# Altium schematic sheet 1_flatsat_interfaces.SchDoc(1_flatsat_interfaces)
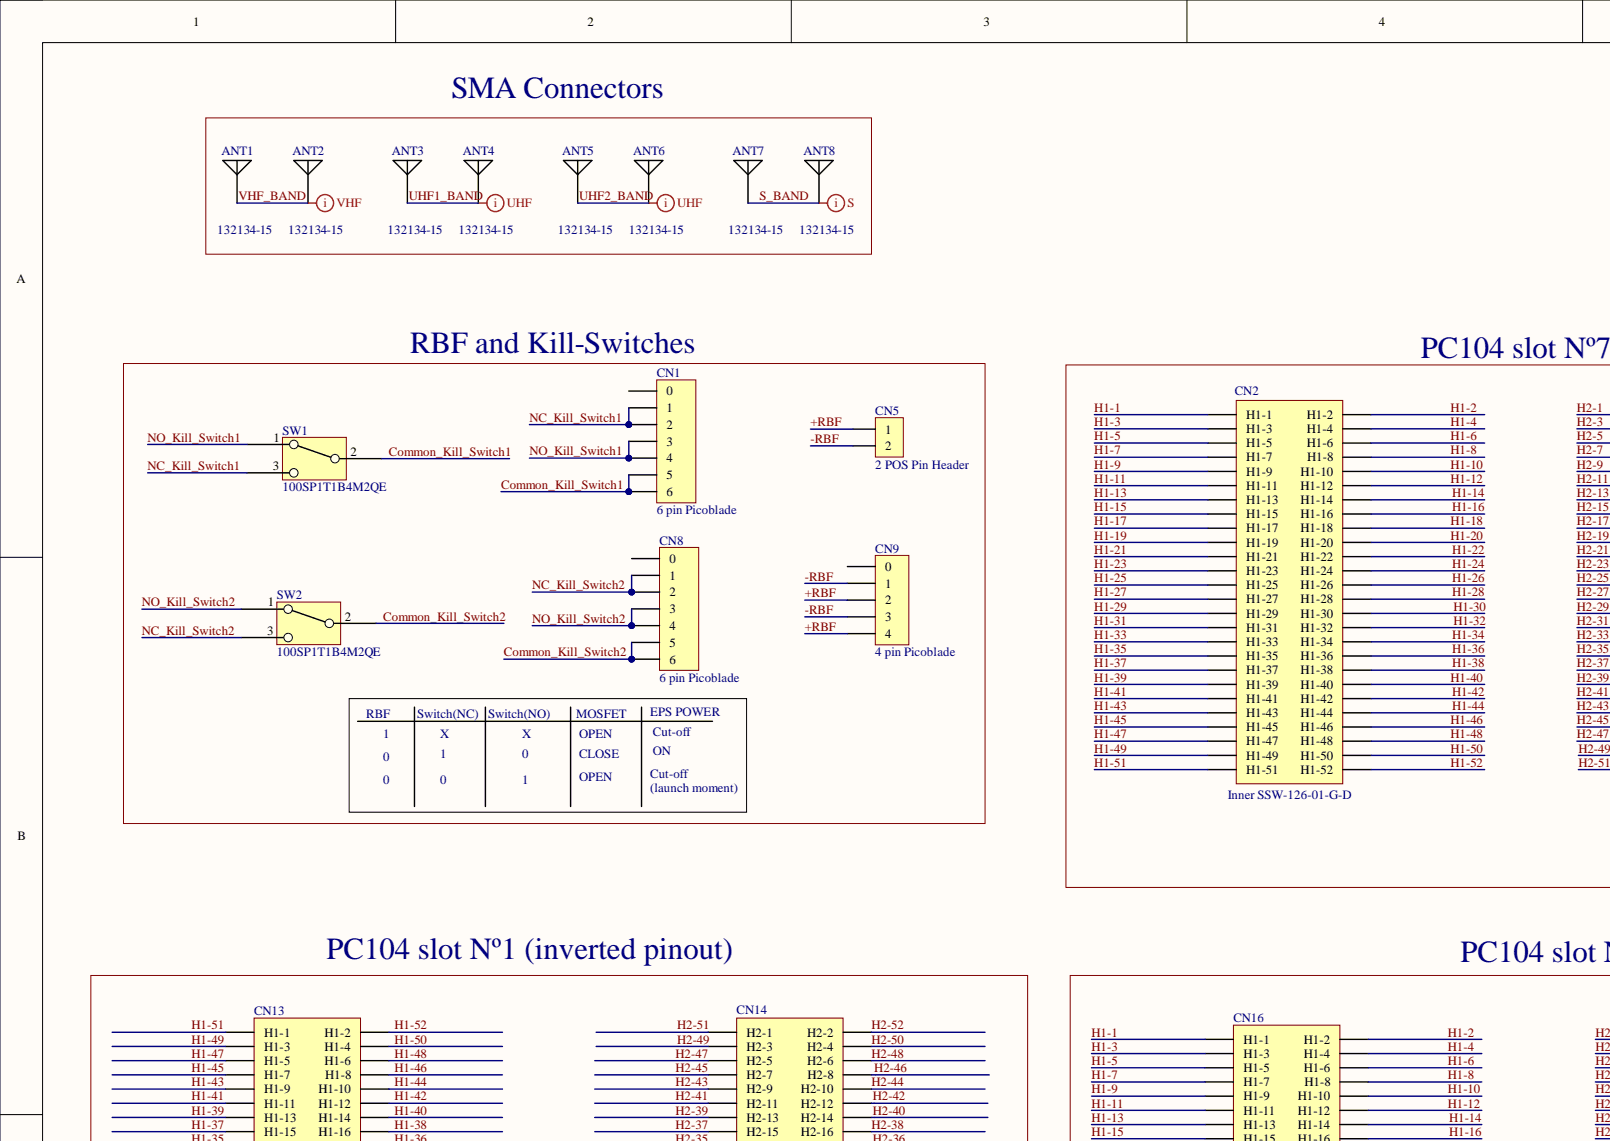
[diagram p0: 1_flatsat_interfaces.SchDoc, top left]
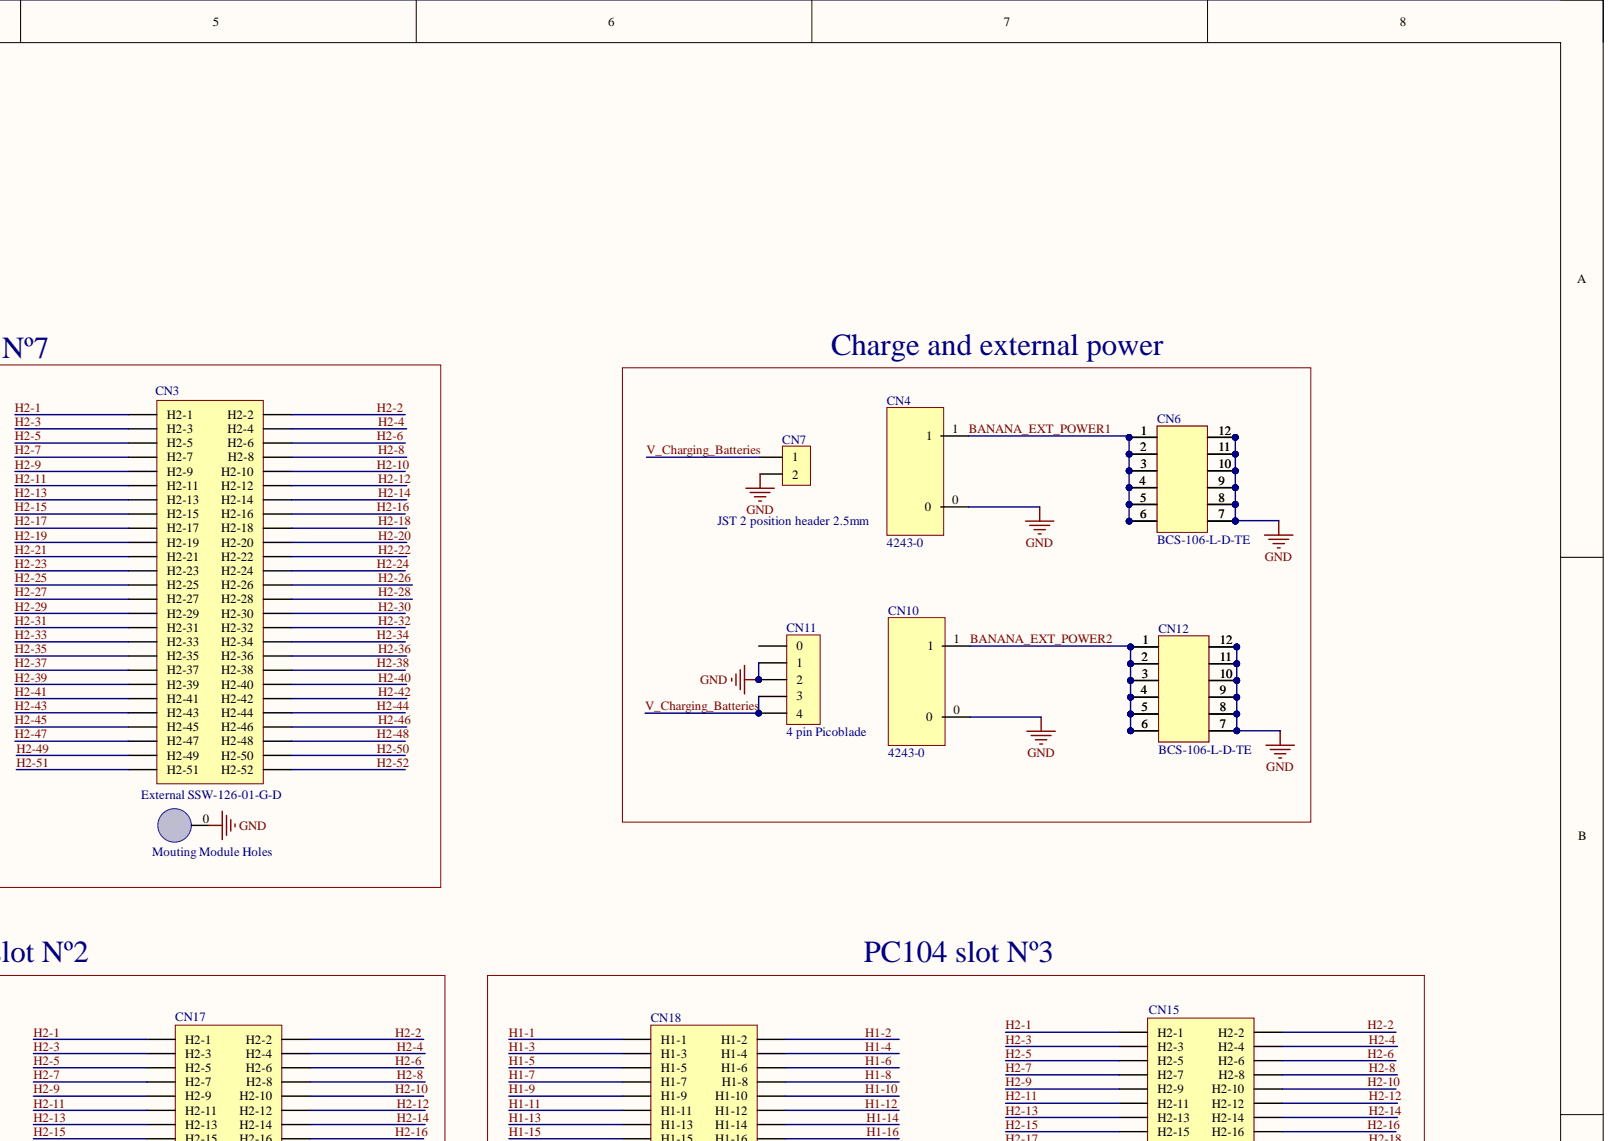
[diagram p1: 1_flatsat_interfaces.SchDoc, top right]
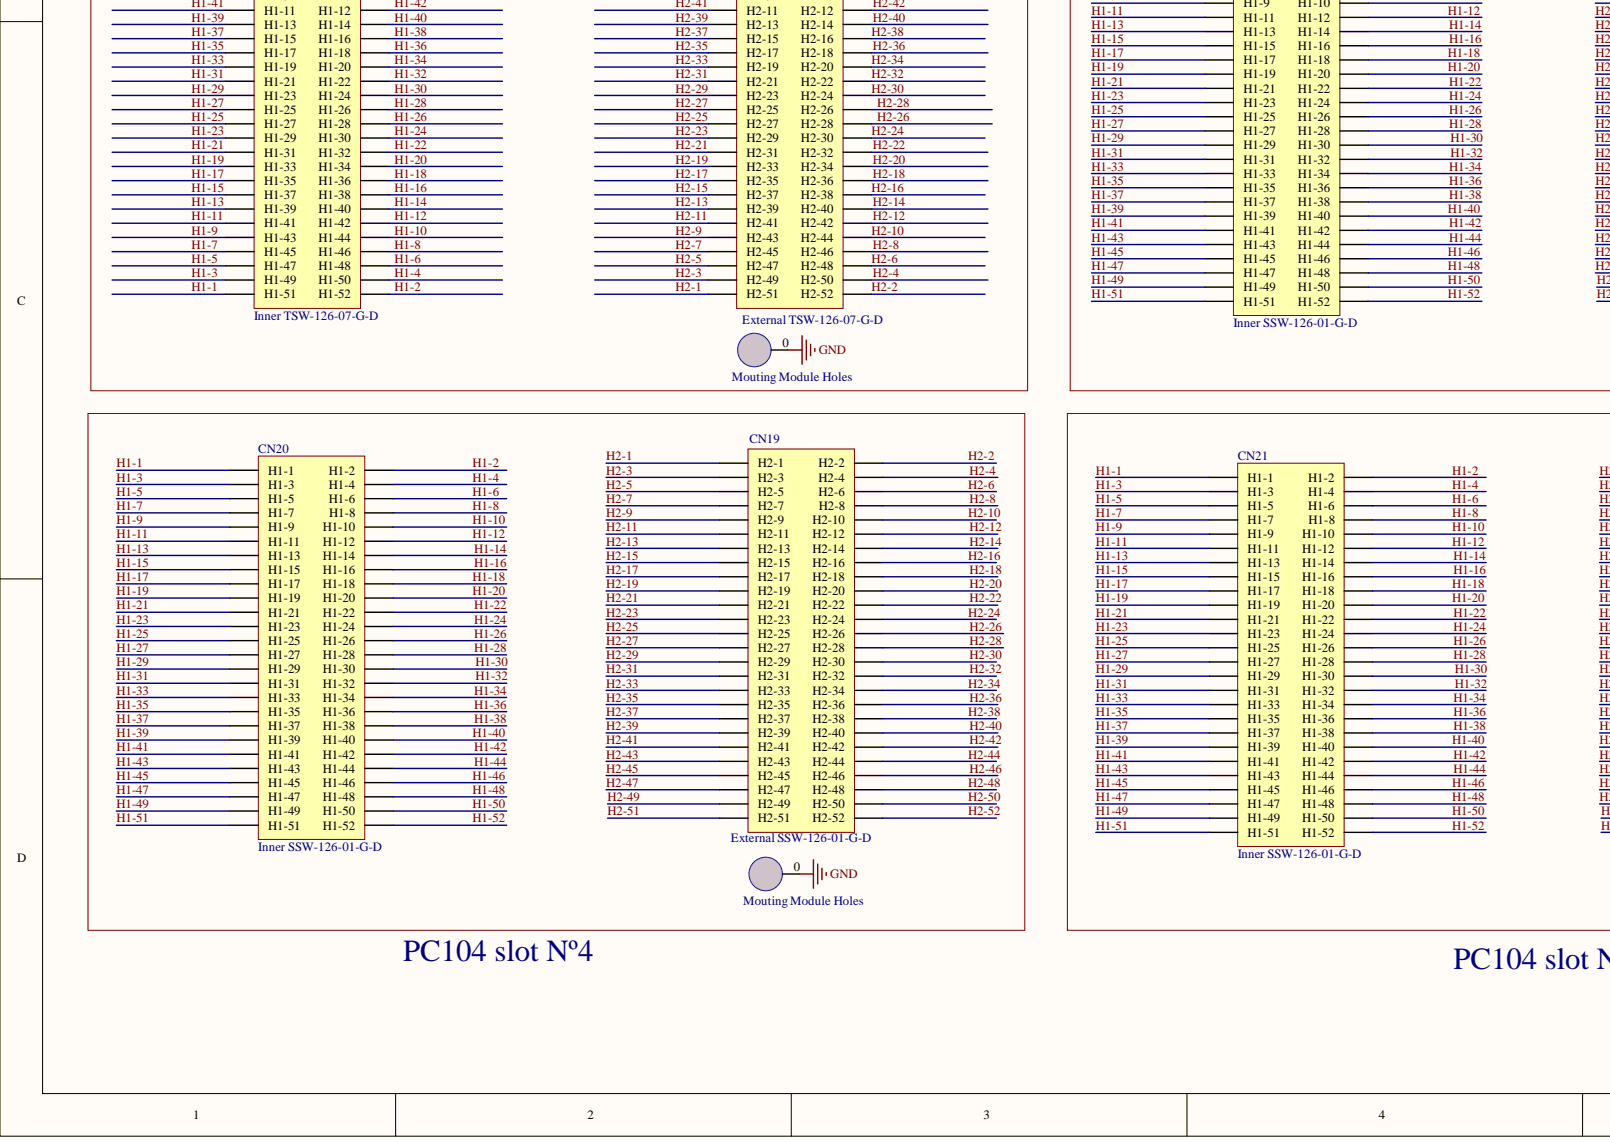
[diagram p2: 1_flatsat_interfaces.SchDoc, bottom left]
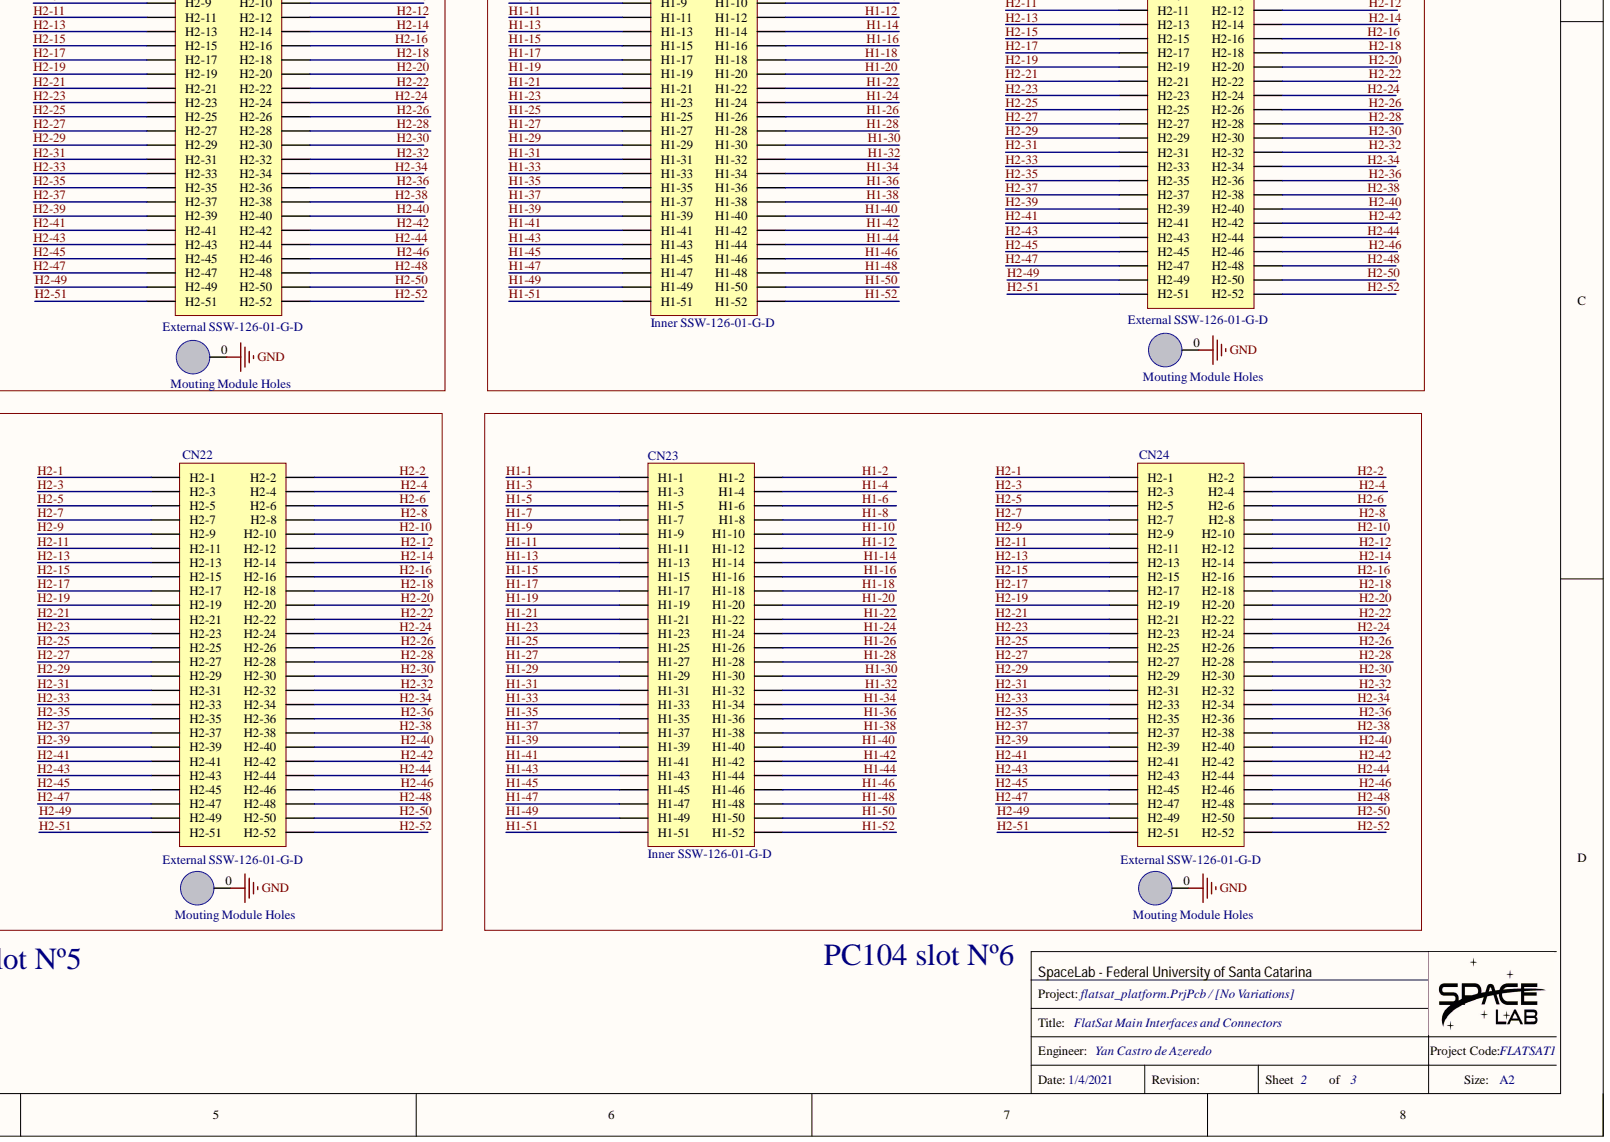
[diagram p3: 1_flatsat_interfaces.SchDoc, bottom right]

## Parts
ANT1  132134-15  [132134-15]
ANT2  132134-15  [132134-15]
ANT3  132134-15  [132134-15]
ANT4  132134-15  [132134-15]
ANT5  132134-15  [132134-15]
ANT6  132134-15  [132134-15]
ANT7  132134-15  [132134-15]
ANT8  132134-15  [132134-15]
CN1  6 pin Picoblade  [PICO BLADE 0533980671]
CN2  Inner SSW-126-01-G-D  Manufacturer Part Number: SSW-126-01-G-D  [Inner_SSW-126-01-G-D_testboard]
CN3  External SSW-126-01-G-D  Manufacturer Part Number: SSW-126-01-G-D  [external_SSW-126-01-G-D _testboard]
CN4  4243-0  [4243-0]
CN5  2 POS Pin Header  [CONN HEADER VERT 2POS 2.54mm]
CN6  BCS-106-L-D-TE  [BCS-106-L-D-TE]
CN7  JST 2 position header 2.5mm  Manufacturer: JST  [B2B-XH-A-M(LF)(SN)]
CN8  6 pin Picoblade  [PICO BLADE 0533980671]
CN9  4 pin Picoblade  [PICO BLADE 0533980471]
CN10  4243-0  [4243-0]
CN11  4 pin Picoblade  [PICO BLADE 0533980471]
CN12  BCS-106-L-D-TE  [BCS-106-L-D-TE]
CN13  Inner TSW-126-07-G-D  Manufacturer Part Number: TSW-126-07-G-D  [Inner_TSW-126-07-G-D]
CN14  External TSW-126-07-G-D  Manufacturer Part Number: TSW-126-07-G-D  [external_TSW-126-07-G-D]
CN15  External SSW-126-01-G-D  Manufacturer Part Number: SSW-126-01-G-D  [external_SSW-126-01-G-D]
CN16  Inner SSW-126-01-G-D  Manufacturer Part Number: SSW-126-01-G-D  [Inner_SSW-126-01-G-D]
CN17  External SSW-126-01-G-D  Manufacturer Part Number: SSW-126-01-G-D  [external_SSW-126-01-G-D]
CN18  Inner SSW-126-01-G-D  Manufacturer Part Number: SSW-126-01-G-D  [Inner_SSW-126-01-G-D]
CN19  External SSW-126-01-G-D  Manufacturer Part Number: SSW-126-01-G-D  [external_SSW-126-01-G-D]
CN20  Inner SSW-126-01-G-D  Manufacturer Part Number: SSW-126-01-G-D  [Inner_SSW-126-01-G-D]
CN21  Inner SSW-126-01-G-D  Manufacturer Part Number: SSW-126-01-G-D  [Inner_SSW-126-01-G-D]
CN22  External SSW-126-01-G-D  Manufacturer Part Number: SSW-126-01-G-D  [external_SSW-126-01-G-D]
CN23  Inner SSW-126-01-G-D  Manufacturer Part Number: SSW-126-01-G-D  [Inner_SSW-126-01-G-D]
CN24  External SSW-126-01-G-D  Manufacturer Part Number: SSW-126-01-G-D  [external_SSW-126-01-G-D]
SW1  100SP1T1B4M2QE  [Toggle Switch SPDT Through Hole]
SW2  100SP1T1B4M2QE  [Toggle Switch SPDT Through Hole]

## Nets
GND: CN3.0 CN4.0 CN6.7 CN6.8 CN6.9 CN6.10 CN6.11 CN6.12 CN7.2 CN10.0 CN11.1 CN11.2 CN12.7 CN12.8 CN12.9 CN12.10 CN12.11 CN12.12 CN14.0 CN15.0 CN17.0 CN19.0 CN22.0 CN24.0
+RBF: CN5.1 CN9.2 CN9.4
-RBF: CN5.2 CN9.1 CN9.3
BANANA_EXT_POWER1: CN4.1 CN6.1 CN6.2 CN6.3 CN6.4 CN6.5 CN6.6
BANANA_EXT_POWER2: CN10.1 CN12.1 CN12.2 CN12.3 CN12.4 CN12.5 CN12.6
Common_Kill_Switch1: CN1.5 CN1.6 SW1.2
Common_Kill_Switch2: CN8.5 CN8.6 SW2.2
H1-1: CN2.H1-1 CN13.H1-51 CN16.H1-1 CN18.H1-1 CN20.H1-1 CN21.H1-1 CN23.H1-1
H1-2: CN2.H1-2 CN13.H1-52 CN16.H1-2 CN18.H1-2 CN20.H1-2 CN21.H1-2 CN23.H1-2
H1-3: CN2.H1-3 CN13.H1-49 CN16.H1-3 CN18.H1-3 CN20.H1-3 CN21.H1-3 CN23.H1-3
H1-4: CN2.H1-4 CN13.H1-50 CN16.H1-4 CN18.H1-4 CN20.H1-4 CN21.H1-4 CN23.H1-4
H1-5: CN2.H1-5 CN13.H1-47 CN16.H1-5 CN18.H1-5 CN20.H1-5 CN21.H1-5 CN23.H1-5
H1-6: CN2.H1-6 CN13.H1-48 CN16.H1-6 CN18.H1-6 CN20.H1-6 CN21.H1-6 CN23.H1-6
H1-7: CN2.H1-7 CN13.H1-45 CN16.H1-7 CN18.H1-7 CN20.H1-7 CN21.H1-7 CN23.H1-7
H1-8: CN2.H1-8 CN13.H1-46 CN16.H1-8 CN18.H1-8 CN20.H1-8 CN21.H1-8 CN23.H1-8
H1-9: CN2.H1-9 CN13.H1-43 CN16.H1-9 CN18.H1-9 CN20.H1-9 CN21.H1-9 CN23.H1-9
H1-10: CN2.H1-10 CN13.H1-44 CN16.H1-10 CN18.H1-10 CN20.H1-10 CN21.H1-10 CN23.H1-10
H1-11: CN2.H1-11 CN13.H1-41 CN16.H1-11 CN18.H1-11 CN20.H1-11 CN21.H1-11 CN23.H1-11
H1-12: CN2.H1-12 CN13.H1-42 CN16.H1-12 CN18.H1-12 CN20.H1-12 CN21.H1-12 CN23.H1-12
H1-13: CN2.H1-13 CN13.H1-39 CN16.H1-13 CN18.H1-13 CN20.H1-13 CN21.H1-13 CN23.H1-13
H1-14: CN2.H1-14 CN13.H1-40 CN16.H1-14 CN18.H1-14 CN20.H1-14 CN21.H1-14 CN23.H1-14
H1-15: CN2.H1-15 CN13.H1-37 CN16.H1-15 CN18.H1-15 CN20.H1-15 CN21.H1-15 CN23.H1-15
H1-16: CN2.H1-16 CN13.H1-38 CN16.H1-16 CN18.H1-16 CN20.H1-16 CN21.H1-16 CN23.H1-16
H1-17: CN2.H1-17 CN13.H1-35 CN16.H1-17 CN18.H1-17 CN20.H1-17 CN21.H1-17 CN23.H1-17
H1-18: CN2.H1-18 CN13.H1-36 CN16.H1-18 CN18.H1-18 CN20.H1-18 CN21.H1-18 CN23.H1-18
H1-19: CN2.H1-19 CN13.H1-33 CN16.H1-19 CN18.H1-19 CN20.H1-19 CN21.H1-19 CN23.H1-19
H1-20: CN2.H1-20 CN13.H1-34 CN16.H1-20 CN18.H1-20 CN20.H1-20 CN21.H1-20 CN23.H1-20
H1-21: CN2.H1-21 CN13.H1-31 CN16.H1-21 CN18.H1-21 CN20.H1-21 CN21.H1-21 CN23.H1-21
H1-22: CN2.H1-22 CN13.H1-32 CN16.H1-22 CN18.H1-22 CN20.H1-22 CN21.H1-22 CN23.H1-22
H1-23: CN2.H1-23 CN13.H1-29 CN16.H1-23 CN18.H1-23 CN20.H1-23 CN21.H1-23 CN23.H1-23
H1-24: CN2.H1-24 CN13.H1-30 CN16.H1-24 CN18.H1-24 CN20.H1-24 CN21.H1-24 CN23.H1-24
H1-25: CN2.H1-25 CN13.H1-27 CN16.H1-25 CN18.H1-25 CN20.H1-25 CN21.H1-25 CN23.H1-25
H1-26: CN2.H1-26 CN13.H1-28 CN16.H1-26 CN18.H1-26 CN20.H1-26 CN21.H1-26 CN23.H1-26
H1-27: CN2.H1-27 CN13.H1-25 CN16.H1-27 CN18.H1-27 CN20.H1-27 CN21.H1-27 CN23.H1-27
H1-28: CN2.H1-28 CN13.H1-26 CN16.H1-28 CN18.H1-28 CN20.H1-28 CN21.H1-28 CN23.H1-28
H1-29: CN2.H1-29 CN13.H1-23 CN16.H1-29 CN18.H1-29 CN20.H1-29 CN21.H1-29 CN23.H1-29
H1-30: CN2.H1-30 CN13.H1-24 CN16.H1-30 CN18.H1-30 CN20.H1-30 CN21.H1-30 CN23.H1-30
H1-31: CN2.H1-31 CN13.H1-21 CN16.H1-31 CN18.H1-31 CN20.H1-31 CN21.H1-31 CN23.H1-31
H1-32: CN2.H1-32 CN13.H1-22 CN16.H1-32 CN18.H1-32 CN20.H1-32 CN21.H1-32 CN23.H1-32
H1-33: CN2.H1-33 CN13.H1-19 CN16.H1-33 CN18.H1-33 CN20.H1-33 CN21.H1-33 CN23.H1-33
H1-34: CN2.H1-34 CN13.H1-20 CN16.H1-34 CN18.H1-34 CN20.H1-34 CN21.H1-34 CN23.H1-34
H1-35: CN2.H1-35 CN13.H1-17 CN16.H1-35 CN18.H1-35 CN20.H1-35 CN21.H1-35 CN23.H1-35
H1-36: CN2.H1-36 CN13.H1-18 CN16.H1-36 CN18.H1-36 CN20.H1-36 CN21.H1-36 CN23.H1-36
H1-37: CN2.H1-37 CN13.H1-15 CN16.H1-37 CN18.H1-37 CN20.H1-37 CN21.H1-37 CN23.H1-37
H1-38: CN2.H1-38 CN13.H1-16 CN16.H1-38 CN18.H1-38 CN20.H1-38 CN21.H1-38 CN23.H1-38
H1-39: CN2.H1-39 CN13.H1-13 CN16.H1-39 CN18.H1-39 CN20.H1-39 CN21.H1-39 CN23.H1-39
H1-40: CN2.H1-40 CN13.H1-14 CN16.H1-40 CN18.H1-40 CN20.H1-40 CN21.H1-40 CN23.H1-40
H1-41: CN2.H1-41 CN13.H1-11 CN16.H1-41 CN18.H1-41 CN20.H1-41 CN21.H1-41 CN23.H1-41
H1-42: CN2.H1-42 CN13.H1-12 CN16.H1-42 CN18.H1-42 CN20.H1-42 CN21.H1-42 CN23.H1-42
H1-43: CN2.H1-43 CN13.H1-9 CN16.H1-43 CN18.H1-43 CN20.H1-43 CN21.H1-43 CN23.H1-43
H1-44: CN2.H1-44 CN13.H1-10 CN16.H1-44 CN18.H1-44 CN20.H1-44 CN21.H1-44 CN23.H1-44
H1-45: CN2.H1-45 CN13.H1-7 CN16.H1-45 CN18.H1-45 CN20.H1-45 CN21.H1-45 CN23.H1-45
H1-46: CN2.H1-46 CN13.H1-8 CN16.H1-46 CN18.H1-46 CN20.H1-46 CN21.H1-46 CN23.H1-46
H1-47: CN2.H1-47 CN13.H1-5 CN16.H1-47 CN18.H1-47 CN20.H1-47 CN21.H1-47 CN23.H1-47
H1-48: CN2.H1-48 CN13.H1-6 CN16.H1-48 CN18.H1-48 CN20.H1-48 CN21.H1-48 CN23.H1-48
H1-49: CN2.H1-49 CN13.H1-3 CN16.H1-49 CN18.H1-49 CN20.H1-49 CN21.H1-49 CN23.H1-49
H1-50: CN2.H1-50 CN13.H1-4 CN16.H1-50 CN18.H1-50 CN20.H1-50 CN21.H1-50 CN23.H1-50
H1-51: CN2.H1-51 CN13.H1-1 CN16.H1-51 CN18.H1-51 CN20.H1-51 CN21.H1-51 CN23.H1-51
H1-52: CN2.H1-52 CN13.H1-2 CN16.H1-52 CN18.H1-52 CN20.H1-52 CN21.H1-52 CN23.H1-52
H2-1: CN3.H2-1 CN14.H2-51 CN15.H2-1 CN17.H2-1 CN19.H2-1 CN22.H2-1 CN24.H2-1
H2-2: CN3.H2-2 CN14.H2-52 CN15.H2-2 CN17.H2-2 CN19.H2-2 CN22.H2-2 CN24.H2-2
H2-3: CN3.H2-3 CN14.H2-49 CN15.H2-3 CN17.H2-3 CN19.H2-3 CN22.H2-3 CN24.H2-3
H2-4: CN3.H2-4 CN14.H2-50 CN15.H2-4 CN17.H2-4 CN19.H2-4 CN22.H2-4 CN24.H2-4
H2-5: CN3.H2-5 CN14.H2-47 CN15.H2-5 CN17.H2-5 CN19.H2-5 CN22.H2-5 CN24.H2-5
H2-6: CN3.H2-6 CN14.H2-48 CN15.H2-6 CN17.H2-6 CN19.H2-6 CN22.H2-6 CN24.H2-6
H2-7: CN3.H2-7 CN14.H2-45 CN15.H2-7 CN17.H2-7 CN19.H2-7 CN22.H2-7 CN24.H2-7
H2-8: CN3.H2-8 CN14.H2-46 CN15.H2-8 CN17.H2-8 CN19.H2-8 CN22.H2-8 CN24.H2-8
H2-9: CN3.H2-9 CN14.H2-43 CN15.H2-9 CN17.H2-9 CN19.H2-9 CN22.H2-9 CN24.H2-9
H2-10: CN3.H2-10 CN14.H2-44 CN15.H2-10 CN17.H2-10 CN19.H2-10 CN22.H2-10 CN24.H2-10
H2-11: CN3.H2-11 CN14.H2-41 CN15.H2-11 CN17.H2-11 CN19.H2-11 CN22.H2-11 CN24.H2-11
H2-12: CN3.H2-12 CN14.H2-42 CN15.H2-12 CN17.H2-12 CN19.H2-12 CN22.H2-12 CN24.H2-12
H2-13: CN3.H2-13 CN14.H2-39 CN15.H2-13 CN17.H2-13 CN19.H2-13 CN22.H2-13 CN24.H2-13
H2-14: CN3.H2-14 CN14.H2-40 CN15.H2-14 CN17.H2-14 CN19.H2-14 CN22.H2-14 CN24.H2-14
H2-15: CN3.H2-15 CN14.H2-37 CN15.H2-15 CN17.H2-15 CN19.H2-15 CN22.H2-15 CN24.H2-15
H2-16: CN3.H2-16 CN14.H2-38 CN15.H2-16 CN17.H2-16 CN19.H2-16 CN22.H2-16 CN24.H2-16
H2-17: CN3.H2-17 CN14.H2-35 CN15.H2-17 CN17.H2-17 CN19.H2-17 CN22.H2-17 CN24.H2-17
H2-18: CN3.H2-18 CN14.H2-36 CN15.H2-18 CN17.H2-18 CN19.H2-18 CN22.H2-18 CN24.H2-18
H2-19: CN3.H2-19 CN14.H2-33 CN15.H2-19 CN17.H2-19 CN19.H2-19 CN22.H2-19 CN24.H2-19
H2-20: CN3.H2-20 CN14.H2-34 CN15.H2-20 CN17.H2-20 CN19.H2-20 CN22.H2-20 CN24.H2-20
H2-21: CN3.H2-21 CN14.H2-31 CN15.H2-21 CN17.H2-21 CN19.H2-21 CN22.H2-21 CN24.H2-21
H2-22: CN3.H2-22 CN14.H2-32 CN15.H2-22 CN17.H2-22 CN19.H2-22 CN22.H2-22 CN24.H2-22
H2-23: CN3.H2-23 CN14.H2-29 CN15.H2-23 CN17.H2-23 CN19.H2-23 CN22.H2-23 CN24.H2-23
H2-24: CN3.H2-24 CN14.H2-30 CN15.H2-24 CN17.H2-24 CN19.H2-24 CN22.H2-24 CN24.H2-24
H2-25: CN3.H2-25 CN14.H2-27 CN15.H2-25 CN17.H2-25 CN19.H2-25 CN22.H2-25 CN24.H2-25
H2-26: CN3.H2-26 CN14.H2-28 CN15.H2-26 CN17.H2-26 CN19.H2-26 CN22.H2-26 CN24.H2-26
H2-27: CN3.H2-27 CN14.H2-25 CN15.H2-27 CN17.H2-27 CN19.H2-27 CN22.H2-27 CN24.H2-27
H2-28: CN3.H2-28 CN14.H2-26 CN15.H2-28 CN17.H2-28 CN19.H2-28 CN22.H2-28 CN24.H2-28
H2-29: CN3.H2-29 CN14.H2-23 CN15.H2-29 CN17.H2-29 CN19.H2-29 CN22.H2-29 CN24.H2-29
H2-30: CN3.H2-30 CN14.H2-24 CN15.H2-30 CN17.H2-30 CN19.H2-30 CN22.H2-30 CN24.H2-30
H2-31: CN3.H2-31 CN14.H2-21 CN15.H2-31 CN17.H2-31 CN19.H2-31 CN22.H2-31 CN24.H2-31
H2-32: CN3.H2-32 CN14.H2-22 CN15.H2-32 CN17.H2-32 CN19.H2-32 CN22.H2-32 CN24.H2-32
H2-33: CN3.H2-33 CN14.H2-19 CN15.H2-33 CN17.H2-33 CN19.H2-33 CN22.H2-33 CN24.H2-33
H2-34: CN3.H2-34 CN14.H2-20 CN15.H2-34 CN17.H2-34 CN19.H2-34 CN22.H2-34 CN24.H2-34
H2-35: CN3.H2-35 CN14.H2-17 CN15.H2-35 CN17.H2-35 CN19.H2-35 CN22.H2-35 CN24.H2-35
H2-36: CN3.H2-36 CN14.H2-18 CN15.H2-36 CN17.H2-36 CN19.H2-36 CN22.H2-36 CN24.H2-36
H2-37: CN3.H2-37 CN14.H2-15 CN15.H2-37 CN17.H2-37 CN19.H2-37 CN22.H2-37 CN24.H2-37
H2-38: CN3.H2-38 CN14.H2-16 CN15.H2-38 CN17.H2-38 CN19.H2-38 CN22.H2-38 CN24.H2-38
H2-39: CN3.H2-39 CN14.H2-13 CN15.H2-39 CN17.H2-39 CN19.H2-39 CN22.H2-39 CN24.H2-39
H2-40: CN3.H2-40 CN14.H2-14 CN15.H2-40 CN17.H2-40 CN19.H2-40 CN22.H2-40 CN24.H2-40
H2-41: CN3.H2-41 CN14.H2-11 CN15.H2-41 CN17.H2-41 CN19.H2-41 CN22.H2-41 CN24.H2-41
H2-42: CN3.H2-42 CN14.H2-12 CN15.H2-42 CN17.H2-42 CN19.H2-42 CN22.H2-42 CN24.H2-42
H2-43: CN3.H2-43 CN14.H2-9 CN15.H2-43 CN17.H2-43 CN19.H2-43 CN22.H2-43 CN24.H2-43
H2-44: CN3.H2-44 CN14.H2-10 CN15.H2-44 CN17.H2-44 CN19.H2-44 CN22.H2-44 CN24.H2-44
H2-45: CN3.H2-45 CN14.H2-7 CN15.H2-45 CN17.H2-45 CN19.H2-45 CN22.H2-45 CN24.H2-45
H2-46: CN3.H2-46 CN14.H2-8 CN15.H2-46 CN17.H2-46 CN19.H2-46 CN22.H2-46 CN24.H2-46
H2-47: CN3.H2-47 CN14.H2-5 CN15.H2-47 CN17.H2-47 CN19.H2-47 CN22.H2-47 CN24.H2-47
H2-48: CN3.H2-48 CN14.H2-6 CN15.H2-48 CN17.H2-48 CN19.H2-48 CN22.H2-48 CN24.H2-48
H2-49: CN3.H2-49 CN14.H2-3 CN15.H2-49 CN17.H2-49 CN19.H2-49 CN22.H2-49 CN24.H2-49
H2-50: CN3.H2-50 CN14.H2-4 CN15.H2-50 CN17.H2-50 CN19.H2-50 CN22.H2-50 CN24.H2-50
H2-51: CN3.H2-51 CN14.H2-1 CN15.H2-51 CN17.H2-51 CN19.H2-51 CN22.H2-51 CN24.H2-51
H2-52: CN3.H2-52 CN14.H2-2 CN15.H2-52 CN17.H2-52 CN19.H2-52 CN22.H2-52 CN24.H2-52
NC_Kill_Switch1: CN1.1 CN1.2 SW1.3
NC_Kill_Switch2: CN8.1 CN8.2 SW2.3
NO_Kill_Switch1: CN1.3 CN1.4 SW1.1
NO_Kill_Switch2: CN8.3 CN8.4 SW2.1
S_BAND: ANT7.1 ANT8.1
UHF1_BAND: ANT3.1 ANT4.1
UHF2_BAND: ANT5.1 ANT6.1
V_Charging_Batteries: CN7.1 CN11.3 CN11.4
VHF_BAND: ANT1.1 ANT2.1

Not connected: CN1 0; CN8 0; CN9 0; CN11 0

## Library data of the parts
ANT1-ANT8 = 132134-15: RF COAXIAL, SMA, STRAIGHT JACK, PCB; Connector Type:SMA Coaxial; Connector Body Style:Straight Jack; Coaxial Termination:Solder; Impedance:50ohm; Coaxial Cable Types:-; Contact Material:Brass; Contact Plating:Gold Plated Contacts; Body Material: Brass; Body Plating: Gold; Connector Type: SMA,Socket; Dielectric Material: PTFE; Impedance: 50R; Mating Cycles: 500; Max Frequency: 12.4GHz; Polarity: Standard; Case/Package: SMA; Category: RF / Coaxial Connectors; Component Kind: Standard; ComponentLink1Description: https://datasheet.ciiva.com/646/132134-15-646945.pdf?src-supplier=Digi-Key; ComponentLink1URL: https://datasheet.ciiva.com/646/132134-15-646945.pdf?src-supplier=Digi-Key; ComponentLink2Description: https://datasheet.ciiva.com/24241/132134-15-customer-drawing-24241080.pdf?src-supplier=Mouser; ComponentLink2URL: https://datasheet.ciiva.com/24241/132134-15-customer-drawing-24241080.pdf?src-supplier=Mouser; Contact Material: Copper; Contact Plating: Gold; Fastening Type: Threaded; Housing Color: Gold; Manufacturer Part Number: 132134-15; Max Operating Temperature: 165°C; Min Operating Temperature: -65°C; Mount: Surface Mount,Through Hole; Orientation: Straight; Package Quantity: 1; Packaging: Bulk; Pricing 1: 1=9.73000, 10=9.30600, 25=8.46000, 50=8.03700, 100=7.82550, 250=6.97952, 500=6.55650, 1000=5.79510, 2500=5.49900 (USD); RoHS: Yes; Stock 1: 25238; Supplier 1: Digi-Key; Supplier Part Number 1: ACX1962-ND; Termination: Solder; Library Name: flatsat_platform.SchLib
CN1 CN8 = 53398-0671: 1.25mm Pitch PicoBlade™ Header, Surface Mount, Vertical, 6 Circuits; Manufacturer: Molex; Body Plating: Tin; Contact Resistance: 20mR; Current Rating: 1A; Gender: Male; Glow Wire Compliant: Non-compliant; Insulation Resistance: 100MR; Number of Circuits: 6; Voltage Rating: 125V; Color: Natural; Component Kind: Standard; ComponentLink1Description: https://www.molex.com/pdm_docs/sd/533980671_sd.pdf?src-supplier=Digi-Key; ComponentLink1URL: https://www.molex.com/pdm_docs/sd/533980671_sd.pdf?src-supplier=Digi-Key; Contact Material: Bronze,Tin; Contact Plating: Tin; Flammability Rating: UL94 V-0; Housing Color: Natural; Housing Material: Nylon; Lead Free: Lead Free; Lead Pitch: 1.25mm; Manufacturer Part Number: 53398-0671; Max Operating Temperature: 85°C; Min Operating Temperature: -40°C; Mount: Surface Mount; Number of Contacts: 6; Number of Positions: 6; Number of Rows: 1; Orientation: Vertical; Package Quantity: 1000; Packaging: Tape and Reel; Pitch: 1.25mm; Pricing 1: 1=1.39, 10=1.26, 100=1.0802, 500=0.90014 (USD); Pricing 2: 1=1.15, 10=1.01, 100=0.864, 500=0.727, 1000=0.427, 2000=0.374 (USD); Radiation Hardening: No; REACH SVHC: Unknown; Stock 1: 21086; Stock 2: 26; Supplier 1: Digi-Key; Supplier 2: Mouser; Supplier Part Number 1: WM7610DKR-ND; Supplier Part Number 2: 538-53398-0671; Termination: Solder; Voltage Rating (AC): 125V; Voltage Rating (DC): 125V; Working Voltage: 125V; Library Name: flatsat_platform.SchLib
CN2 = Inner_Expansion_TestBoard_PC104: Conn Socket Strip SKT 52 POS 2.54mm Solder ST Thru-Hole; Manufacturer: Samtec; Connector Type: Receptacle,Socket; Contact Resistance: 10mR; Gender: Receptacle; Mating Cycles: 100; Max Current Rating: 5.5A; Max Voltage Rating (AC): 550V; Number of Contacts: 52; Row Spacing: 0.1inch; Category: Headers and Wire Housings; Color: Black; Component Kind: Standard; ComponentLink1Description: https://datasheet.ciiva.com/749/ssw-1xx-xx-xxx-x-xx-xxx-xx-mkt-749805.pdf?src-supplier=Digi-Key; ComponentLink1URL: https://datasheet.ciiva.com/749/ssw-1xx-xx-xxx-x-xx-xxx-xx-mkt-749805.pdf?src-supplier=Digi-Key; Contact Material: Bronze; Contact Plating: Gold; Depth: 4.95mm; Housing Color: Black; Housing Material: Polymer; Lead Free: Lead Free; Length: 66.55mm; Max Operating Temperature: 125°C; Min Operating Temperature: -55°C; Mount: Through Hole; Number of Positions: 52; Number of Rows: 2; Orientation: Straight; Packaging: Bulk; Pitch: 2.54mm; Pricing 1: 1=6.95000, 10=6.17000, 25=5.80000, 100=5.30000, 250=4.64000, 500=4.22000 (USD); REACH SVHC: No SVHC; RoHS: Yes; Stock 1: 72; Supplier 1: Mouser; Supplier Part Number 1: 200-SSW12601GD; Termination: Solder; Width: 4.95mm; Library Name: flatsat_platform.SchLib
CN3 = External_Expansion_TestBoard_PC104: Conn Socket Strip SKT 52 POS 2.54mm Solder ST Thru-Hole; Manufacturer: Samtec; Connector Type: Receptacle,Socket; Contact Resistance: 10mR; Gender: Receptacle; Mating Cycles: 100; Max Current Rating: 5.5A; Max Voltage Rating (AC): 550V; Number of Contacts: 52; Row Spacing: 0.1inch; Category: Headers and Wire Housings; Color: Black; Component Kind: Standard; ComponentLink1Description: https://datasheet.ciiva.com/749/ssw-1xx-xx-xxx-x-xx-xxx-xx-mkt-749805.pdf?src-supplier=Digi-Key; ComponentLink1URL: https://datasheet.ciiva.com/749/ssw-1xx-xx-xxx-x-xx-xxx-xx-mkt-749805.pdf?src-supplier=Digi-Key; Contact Material: Bronze; Contact Plating: Gold; Depth: 4.95mm; Housing Color: Black; Housing Material: Polymer; Lead Free: Lead Free; Length: 66.55mm; Max Operating Temperature: 125°C; Min Operating Temperature: -55°C; Mount: Through Hole; Number of Positions: 52; Number of Rows: 2; Orientation: Straight; Packaging: Bulk; Pitch: 2.54mm; Pricing 1: 1=6.95000, 10=6.17000, 25=5.80000, 100=5.30000, 250=4.64000, 500=4.22000 (USD); REACH SVHC: No SVHC; RoHS: Yes; Stock 1: 72; Supplier 1: Mouser; Supplier Part Number 1: 200-SSW12601GD; Termination: Solder; Width: 4.95mm; Library Name: flatsat_platform.SchLib
CN4 CN10 = 4243-0: POMONA, 4243-0, Binding Post, 4243 Series, 15 A, 60 VDC, Tin Plated Contacts, Brass, Black; Manufacturer: Pomona Electronics; Current Rating: 15A; Hole Diameter: 0.1inch; Insulation: Insulated; Number of Ports: 2; Panel Thickness: 6.35mm; Voltage Rating: 2.5kV; Voltage Rating (AC): 30V; Voltage Rating (DC): 60V; Body Material: Brass; Category: Connectors; Color: Black,Red; Component Kind: Standard; ComponentLink1Description: https://datasheet.ciiva.com/1453/d4243-1-01-1453890.pdf?src-supplier=Element14; ComponentLink1URL: https://datasheet.ciiva.com/1453/d4243-1-01-1453890.pdf?src-supplier=Element14; ComponentLink2Description: https://datasheet.ciiva.com/16883/70198115-16883751.pdf?src-supplier=Allied+Electronics; ComponentLink2URL: https://datasheet.ciiva.com/16883/70198115-16883751.pdf?src-supplier=Allied+Electronics; ComponentLink3Description: https://datasheet.ciiva.com/30105/d4243-1-02-30105753.pdf?src-supplier=Onlinecomponents.com; ComponentLink3URL: https://datasheet.ciiva.com/30105/d4243-1-02-30105753.pdf?src-supplier=Onlinecomponents.com; Contact Material: Brass; Contact Plating: Tin,Bright; Depth: 11.18mm; Housing Color: Black; Housing Material: Polycarbonate; Insulation Material: Polycarbonate; Lead Free: Lead Free; Length: 30.23mm; Manufacturer Part Number: 4243-0; Max Current Rating: 15A; Mount: Panel; Number of Pins: 1; Orientation: Straight; Package Quantity: 5; Packaging: Bulk; Pricing 1: 1=8.99000, 25=8.54040, 50=8.09100, 100=7.73150 (USD); REACH SVHC: No SVHC; RoHS: Yes; Stock 1: 327; Supplier 1: Digi-Key; Supplier Part Number 1: 501-1126-ND; Termination: Turret; Library Name: flatsat_platform.SchLib
CN5 = M20-9990245: M20 HDR, PIN, SIL, VERT, 2W; Manufacturer: Harwin; Connector Type: Male Pin; Contact Resistance: 25mR; Current: 3A; Gender: Male; Insulation Resistance: 10GR; Mating Cycles: 300; Number of Contacts: 2; Pitch: 2.54mm; Voltage: 50V; Voltage Rating (AC): 750V; Case/Package: SIL; Color: Black; Component Kind: Standard; ComponentLink1Description: http://www.farnell.com/datasheets/2368211.pdf?src-supplier=Element14; ComponentLink1URL: http://www.farnell.com/datasheets/2368211.pdf?src-supplier=Element14; ComponentLink2Description: http://uk.rs-online.com/webdocs/1388/0900766b81388728.pdf?src-supplier=RSComponents; ComponentLink2URL: http://uk.rs-online.com/webdocs/1388/0900766b81388728.pdf?src-supplier=RSComponents; ComponentLink3Description: https://cdn.harwin.com/pdfs/Harwin_PC_Connectors_M20.pdf?src-supplier=Digi-Key; ComponentLink3URL: https://cdn.harwin.com/pdfs/Harwin_PC_Connectors_M20.pdf?src-supplier=Digi-Key; ComponentLink4Description: http://www.mouser.com/ds/2/181/Harwin_Product_Catalog_page_206-1134556.pdf?src-supplier=Mouser; ComponentLink4URL: http://www.mouser.com/ds/2/181/Harwin_Product_Catalog_page_206-1134556.pdf?src-supplier=Mouser; Contact Material: Brass,Bronze; Contact Mating Length: 0.24inch; Contact Plating: Gold; Current Rating: 3A; Depth: 2.54mm; Height: 0.12inch; Housing Color: Black; Housing Material: Nylon; Lead Pitch: 2.54mm; Length: 5.08mm; Manufacturer Part Number: M20-9990245; Max Current Rating: 3A; Max Operating Temperature: 105°C; Min Operating Temperature: -40°C; Mount: Through Hole; Number of Poles: 2; Number of Positions: 2; Number of Rows: 1; Orientation: Straight; Package Quantity: 1000; Packaging: Bag; Pricing 1: 1=0.15, 10=0.136, 100=0.0841, 500=0.0696, 1000=0.0609, 5000=0.0522, 10000=0.05075 (USD); Pricing 2: 1=0.14, 10=0.083, 100=0.069, 1000=0.06, 2000=0.052, 10000=0.05 (USD); Radiation Hardening: No; REACH SVHC: No SVHC; Stock 1: 27298; Stock 2: 26881; Supplier 1: Digi-Key; Supplier 2: Mouser; Supplier Part Number 1: 952-2261-ND; Supplier Part Number 2: 855-M20-9990245; Termination: Solder; Weight: 0.25lb; Width: 0.25inch; Working Voltage: 750V; Library Name: flatsat_platform.SchLib
CN6 CN12 = BCS-106-L-D-TE: CONN RCPT 12POS 0.1 GOLD PCB; Manufacturer: Samtec; Connector Type: Receptacle; Contact Resistance: 10mR; Max Current Rating: 5.9A; Max Voltage Rating (AC): 475V; Number of Contacts: 12; Pitch: 2.54mm; Row Spacing: 0.1inch; Category: Connectors; Color: Black; Component Kind: Standard; ComponentLink1Description: https://datasheet.ciiva.com/16706/bcs-16706444.pdf?src-supplier=Avnet+Europe; ComponentLink1URL: https://datasheet.ciiva.com/16706/bcs-16706444.pdf?src-supplier=Avnet+Europe; ComponentLink2Description: https://datasheet.ciiva.com/413/bcs-1xx-xxx-x-xx-xxx-mkt-413758.pdf?src-supplier=Digi-Key; ComponentLink2URL: https://datasheet.ciiva.com/413/bcs-1xx-xxx-x-xx-xxx-mkt-413758.pdf?src-supplier=Digi-Key; Contact Material: Bronze; Contact Plating: Gold; Depth: 5.08mm; Gender: Receptacle; Housing Color: Black; Housing Material: Polymer; Lead Free: Lead Free; Length: 15.24mm; Manufacturer Part Number: BCS-106-L-D-TE; Max Operating Temperature: 125°C; Min Operating Temperature: -55°C; Mount: Through Hole; Number of Positions: 12; Number of Rows: 2; Orientation: Vertical; Packaging: Rail/Tube; Pricing 1: 1=1.77000, 10=1.60200, 25=1.50360, 50=1.43820, 100=1.37290, 250=1.24216, 500=1.14408 (USD); Radiation Hardening: No; REACH SVHC: No SVHC; RoHS: Yes; Stock 1: 7411; Supplier 1: Digi-Key; Supplier Part Number 1: SAM1008-06-ND; Termination: Solder; Width: 5.08mm; Library Name: flatsat_platform.SchLib
CN7 = B2B-XH-A-M(LF)(SN): CONN HEADER TOP 2POS 2.5MM GRN; Connector Type: Male Pin; Number of Positions: 2; Pitch: 0.098inch; Color: Natural; Component Kind: Standard; ComponentLink1Description: http://www.jst-mfg.com/product/pdf/eng/eXH.pdf; ComponentLink1URL: http://www.jst-mfg.com/product/pdf/eng/eXH.pdf; Contact Plating: Tin; Fastening Type: Detent; Manufacturer Part Number: B2B-XH-A-M(LF)(SN); Mount: Through Hole; Number of Rows: 1; Package Quantity: 1000; Packaging: Bulk; Pricing 1: 1=0.17, 10=0.157, 100=0.0966, 500=0.07998, 1000=0.06999, 5000=0.05999, 10000=0.05832 (USD); Stock 1: 5564; Supplier 1: Digi-Key; Supplier Part Number 1: 455-2879-ND; Termination: Press-Fit; Library Name: flatsat_platform.SchLib
CN9 CN11 = 0533980471: Connector Header Surface Mount 4 position 0.049" (1.25mm); Manufacturer: Molex; Manufacturer Part Number: 53398-0471; Body Plating: Tin; Contact Resistance: 20mR; Current Rating: 1A; Gender: Male; Glow Wire Compliant: Non-compliant; Insulation Resistance: 100MR; N of Positions: 4; Voltage Rating: 125V; Color: Natural; Component Kind: Standard; ComponentLink1Description: http://www.mouser.com/ds/2/276/0533980471_PCB_HEADERS-171069.pdf?src-supplier=Mouser; ComponentLink1URL: http://www.mouser.com/ds/2/276/0533980471_PCB_HEADERS-171069.pdf?src-supplier=Mouser; ComponentLink2Description: http://www.jameco.com/Jameco/Products/ProdDS/1932627.pdf?src-supplier=Jameco; ComponentLink2URL: http://www.jameco.com/Jameco/Products/ProdDS/1932627.pdf?src-supplier=Jameco; ComponentLink3Description: https://www.distrelec.biz/Web/Downloads/46/_e/gf116833-116846_e.pdf?mime=application%2Fpdf&src-supplier=Distrelec; ComponentLink3URL: https://www.distrelec.biz/Web/Downloads/46/_e/gf116833-116846_e.pdf?mime=application%2Fpdf&src-supplier=Distrelec; ComponentLink4Description: http://www.molex.com/webdocs/datasheets/pdf/en-us/0533980471_PCB_HEADERS.pdf?src-supplier=Element14; ComponentLink4URL: http://www.molex.com/webdocs/datasheets/pdf/en-us/0533980471_PCB_HEADERS.pdf?src-supplier=Element14; ComponentLink5Description: http://www.farnell.com/datasheets/1867381.pdf?src-supplier=Element14; ComponentLink5URL: http://www.farnell.com/datasheets/1867381.pdf?src-supplier=Element14; ComponentLink6Description: http://uk.rs-online.com/webdocs/13c4/0900766b813c4500.pdf?src-supplier=RSComponents; ComponentLink6URL: http://uk.rs-online.com/webdocs/13c4/0900766b813c4500.pdf?src-supplier=RSComponents; ComponentLink7Description: http://www.molex.com/webdocs/datasheets/pdf/en-us/0533980471_PCB_HEADERS.pdf?src-supplier=Farnell; ComponentLink7URL: http://www.molex.com/webdocs/datasheets/pdf/en-us/0533980471_PCB_HEADERS.pdf?src-supplier=Farnell; ComponentLink8Description: http://www.farnell.com/datasheets/2697809.pdf?src-supplier=Element14; ComponentLink8URL: http://www.farnell.com/datasheets/2697809.pdf?src-supplier=Element14; ComponentLink9Description: http://www.molex.com/webdocs/datasheets/pdf/en-us/0533980471_PCB_HEADERS.pdf?src-supplier=Avnet; ComponentLink9URL: http://www.molex.com/webdocs/datasheets/pdf/en-us/0533980471_PCB_HEADERS.pdf?src-supplier=Avnet; ComponentLink10Description: http://www.molex.com/webdocs/datasheets/pdf/en-us/0533980471_PCB_HEADERS.pdf?src-supplier=Avnet+Europe; ComponentLink10URL: http://www.molex.com/webdocs/datasheets/pdf/en-us/0533980471_PCB_HEADERS.pdf?src-supplier=Avnet+Europe; Contact Material: Bronze,Tin,Brass; Contact Plating: Tin; Flammability Rating: UL94 V-0; Housing Color: Natural; Housing Material: Nylon; Lead Free: Lead Free; Lead Pitch: 1.25mm; Max Operating Temperature: 85°C; Min Operating Temperature: -40°C; Mount: Surface Mount; Mount Type: SMD; Number of Circuits: 4; Number of Contacts: 4; Number of Positions: 4; Number of Rows: 1; Orientation: Vertical; Package Quantity: 1000; Packaging: Tape and Reel; Pitch: 1.25mm; Pricing 1: 1=1.19, 10=1.044, 100=0.901, 500=0.73716 (USD); Pricing 2: 1=0.98, 10=0.832, 100=0.716, 500=0.6, 1000=0.391, 2000=0.342 (USD); Radiation Hardening: No; REACH SVHC: Unknown; Stock 1: 21236; Stock 2: 43680; Supplier 1: Digi-Key; Supplier 2: Mouser; Supplier Part Number 1: WM7608CT-ND; Supplier Part Number 2: 538-53398-0471; Termination: Solder; Value: 0533980471; Voltage Rating (AC): 125V; Voltage Rating (DC): 125V; Working Voltage: 125V; Library Name: flatsat_platform.SchLib
CN13 = Inner_ TSW-126-07-G-D: SAMTEC         TSW-126-07-G-D             Board-To-Board Connector, Vertical, TSW Series, 52 Contacts, Header, 2.54 mm, Through Hole, 2 Rows; Connector Type: Male Pin; Gender: Male; Number of Contacts: 52; Pitch: 0.1inch; Category: Headers and Wire Housings; Color: Black; Component Kind: Standard; ComponentLink1Description: http://www.farnell.com/datasheets/2060109.pdf?src-supplier=Farnell; ComponentLink1URL: http://www.farnell.com/datasheets/2060109.pdf?src-supplier=Farnell; ComponentLink2Description: http://www.farnell.com/datasheets/2060109.pdf?src-supplier=Newark; ComponentLink2URL: http://www.farnell.com/datasheets/2060109.pdf?src-supplier=Newark; ComponentLink3Description: http://suddendocs.samtec.com/prints/tsw-xxx-xx-x-x-xx-xxx-footprint.pdf?src-supplier=Digi-Key; ComponentLink3URL: http://suddendocs.samtec.com/prints/tsw-xxx-xx-x-x-xx-xxx-footprint.pdf?src-supplier=Digi-Key; Contact Material: Bronze; Contact Mating Length: 0.23inch; Contact Plating: Gold; Height: 2.54mm; Housing Color: Black; Housing Material: Plastic; Lead Free: Lead Free; Length: 66mm; Manufacturer: Samtec; Mount: Through Hole; Number of Positions: 52; Number of Rows: 2; Package Quantity: 1; Packaging: Bulk; Pricing 1: 1=5.05000, 10=4.65000, 25=4.27000, 50=4.08000, 100=3.88000, 250=3.40000, 500=3.30000 (USD); REACH SVHC: No SVHC; RoHS: Yes; Row Spacing: 0.1inch; Stock 1: 100; Supplier 1: Newark; Supplier Part Number 1: 12P2847; Termination: Solder; Width: 5.08mm; Library Name: flatsat_platform.SchLib
CN14 = External_ TSW-126-07-G-D: SAMTEC         TSW-126-07-G-D             Board-To-Board Connector, Vertical, TSW Series, 52 Contacts, Header, 2.54 mm, Through Hole, 2 Rows; Connector Type: Male Pin; Gender: Male; Number of Contacts: 52; Pitch: 0.1inch; Category: Headers and Wire Housings; Color: Black; Component Kind: Standard; ComponentLink1Description: http://www.farnell.com/datasheets/2060109.pdf?src-supplier=Farnell; ComponentLink1URL: http://www.farnell.com/datasheets/2060109.pdf?src-supplier=Farnell; ComponentLink2Description: http://www.farnell.com/datasheets/2060109.pdf?src-supplier=Newark; ComponentLink2URL: http://www.farnell.com/datasheets/2060109.pdf?src-supplier=Newark; ComponentLink3Description: http://suddendocs.samtec.com/prints/tsw-xxx-xx-x-x-xx-xxx-footprint.pdf?src-supplier=Digi-Key; ComponentLink3URL: http://suddendocs.samtec.com/prints/tsw-xxx-xx-x-x-xx-xxx-footprint.pdf?src-supplier=Digi-Key; Contact Material: Bronze; Contact Mating Length: 0.23inch; Contact Plating: Gold; Height: 2.54mm; Housing Color: Black; Housing Material: Plastic; Lead Free: Lead Free; Length: 66mm; Manufacturer: Samtec; Mount: Through Hole; Number of Positions: 52; Number of Rows: 2; Package Quantity: 1; Packaging: Bulk; Pricing 1: 1=5.05000, 10=4.65000, 25=4.27000, 50=4.08000, 100=3.88000, 250=3.40000, 500=3.30000 (USD); REACH SVHC: No SVHC; RoHS: Yes; Row Spacing: 0.1inch; Stock 1: 100; Supplier 1: Newark; Supplier Part Number 1: 12P2847; Termination: Solder; Width: 5.08mm; Library Name: flatsat_platform.SchLib
CN15 CN17 CN19 CN22 CN24 = External_SSW-126-01-G-D: 52 Position Receptacle Connector  Through Hole; Manufacturer: Samtec; Connector Type: Receptacle,Socket; Contact Resistance: 10mR; Mating Cycles: 100; Max Current Rating: 5.5A; Max Voltage Rating (AC): 550V; Number of Contacts: 52; Pitch: 2.54mm; Row Spacing: 0.1inch; Color: Black; Component Kind: Standard; ComponentLink1Description: http://suddendocs.samtec.com/prints/ssw-1xx-xx-xxx-x-xx-xxx-xx-mkt.pdf?src-supplier=Digi-Key; ComponentLink1URL: http://suddendocs.samtec.com/prints/ssw-1xx-xx-xxx-x-xx-xxx-xx-mkt.pdf?src-supplier=Digi-Key; Contact Material: Bronze; Contact Plating: Gold; Depth: 4.95mm; Gender: Receptacle; Housing Color: Black; Housing Material: Polymer; Lead Free: Lead Free; Length: 66.55mm; Max Operating Temperature: 125°C; Min Operating Temperature: -55°C; Mount: Through Hole; Number of Positions: 52; Number of Rows: 2; Orientation: Straight; Packaging: Bulk; Partnumber: SSW-126-01-G-D; Pricing 1: 1=6.95, 10=5.8, 100=4.93, 250=4.4, 500=4.05, 1000=3.8 (USD); Pricing 2: 1=6.69, 10=6.415, 25=5.8576, 50=5.5786, 100=5.2996, 250=4.74176, 500=4.46284 (USD); REACH SVHC: No SVHC; Stock 1: 86; Stock 2: 0; Supplier 1: Mouser; Supplier 2: Digi-Key; Supplier Part Number 1: 200-SSW12601GD; Supplier Part Number 2: SAM1208-26-ND; Termination: Solder; Width: 4.95mm; Library Name: flatsat_platform.SchLib
CN16 CN18 CN20 CN21 CN23 = Inner_SSW-126-01-G-D: 52 Position Receptacle Connector  Through Hole; Manufacturer: Samtec; Connector Type: Receptacle,Socket; Contact Resistance: 10mR; Mating Cycles: 100; Max Current Rating: 5.5A; Max Voltage Rating (AC): 550V; Number of Contacts: 52; Pitch: 2.54mm; Row Spacing: 0.1inch; Color: Black; Component Kind: Standard; ComponentLink1Description: http://suddendocs.samtec.com/prints/ssw-1xx-xx-xxx-x-xx-xxx-xx-mkt.pdf?src-supplier=Digi-Key; ComponentLink1URL: http://suddendocs.samtec.com/prints/ssw-1xx-xx-xxx-x-xx-xxx-xx-mkt.pdf?src-supplier=Digi-Key; Contact Material: Bronze; Contact Plating: Gold; Depth: 4.95mm; Gender: Receptacle; Housing Color: Black; Housing Material: Polymer; Lead Free: Lead Free; Length: 66.55mm; Max Operating Temperature: 125°C; Min Operating Temperature: -55°C; Mount: Through Hole; Number of Positions: 52; Number of Rows: 2; Orientation: Straight; Packaging: Bulk; Partnumber: SSW-126-01-G-D; Pricing 1: 1=6.95, 10=5.8, 100=4.93, 250=4.4, 500=4.05, 1000=3.8 (USD); Pricing 2: 1=6.69, 10=6.415, 25=5.8576, 50=5.5786, 100=5.2996, 250=4.74176, 500=4.46284 (USD); REACH SVHC: No SVHC; Stock 1: 86; Stock 2: 0; Supplier 1: Mouser; Supplier 2: Digi-Key; Supplier Part Number 1: 200-SSW12601GD; Supplier Part Number 2: SAM1208-26-ND; Termination: Solder; Width: 4.95mm; Library Name: flatsat_platform.SchLib
SW1 SW2 = 100SP1T1B4M2QE: E-SWITCH - 100SP1T1B4M2QE - SWITCH, TOGGLE, SPDT, 5A, 120VAC, 28VDC; Actuator Length: 12.19mm; Actuator Material: Brass,Chrome; Actuator Type: Standard Round; Electrical Life: 40000Cycles; Illumination Type: Non-Illuminated,Illuminated; Switch Function: On-On; Voltage Rating (AC): 120V; Voltage Rating (DC): 28V; Category: Toggle Switches; Component Kind: Standard; ComponentLink1Description: https://datasheet.ciiva.com/24455/t111597-24455739.pdf?src-supplier=Onlinecomponents.com; ComponentLink1URL: https://datasheet.ciiva.com/24455/t111597-24455739.pdf?src-supplier=Onlinecomponents.com; ComponentLink2Description: https://datasheet.ciiva.com/2905/t111597-2905494.pdf?src-supplier=Digi-Key; ComponentLink2URL: https://datasheet.ciiva.com/2905/t111597-2905494.pdf?src-supplier=Digi-Key; Contact Material: Silver; Contact Plating: Silver; Current Rating: 5A; Lead Free: Lead Free; Manufacturer Part Number: 100SP1T1B4M2QE; Max Operating Temperature: 85°C; Min Operating Temperature: -30°C; Mount: Through Hole; Orientation: Vertical; Package Quantity: 1; Packaging: Bulk; Pricing 1: 1=1.99000, 10=1.77900, 25=1.68240, 50=1.60200, 100=1.52950, 250=1.46512, 500=1.36850, 1000=1.30410 (USD); RoHS: Yes; Stock 1: 8901; Supplier 1: Digi-Key; Supplier Part Number 1: EG2355-ND; Termination: PC Pin; Throw Configuration: SPDT; Library Name: flatsat_platform.SchLib
